# Altium schematic sheet TSAL_Rev3.SchDoc(TSAL_Rev3)
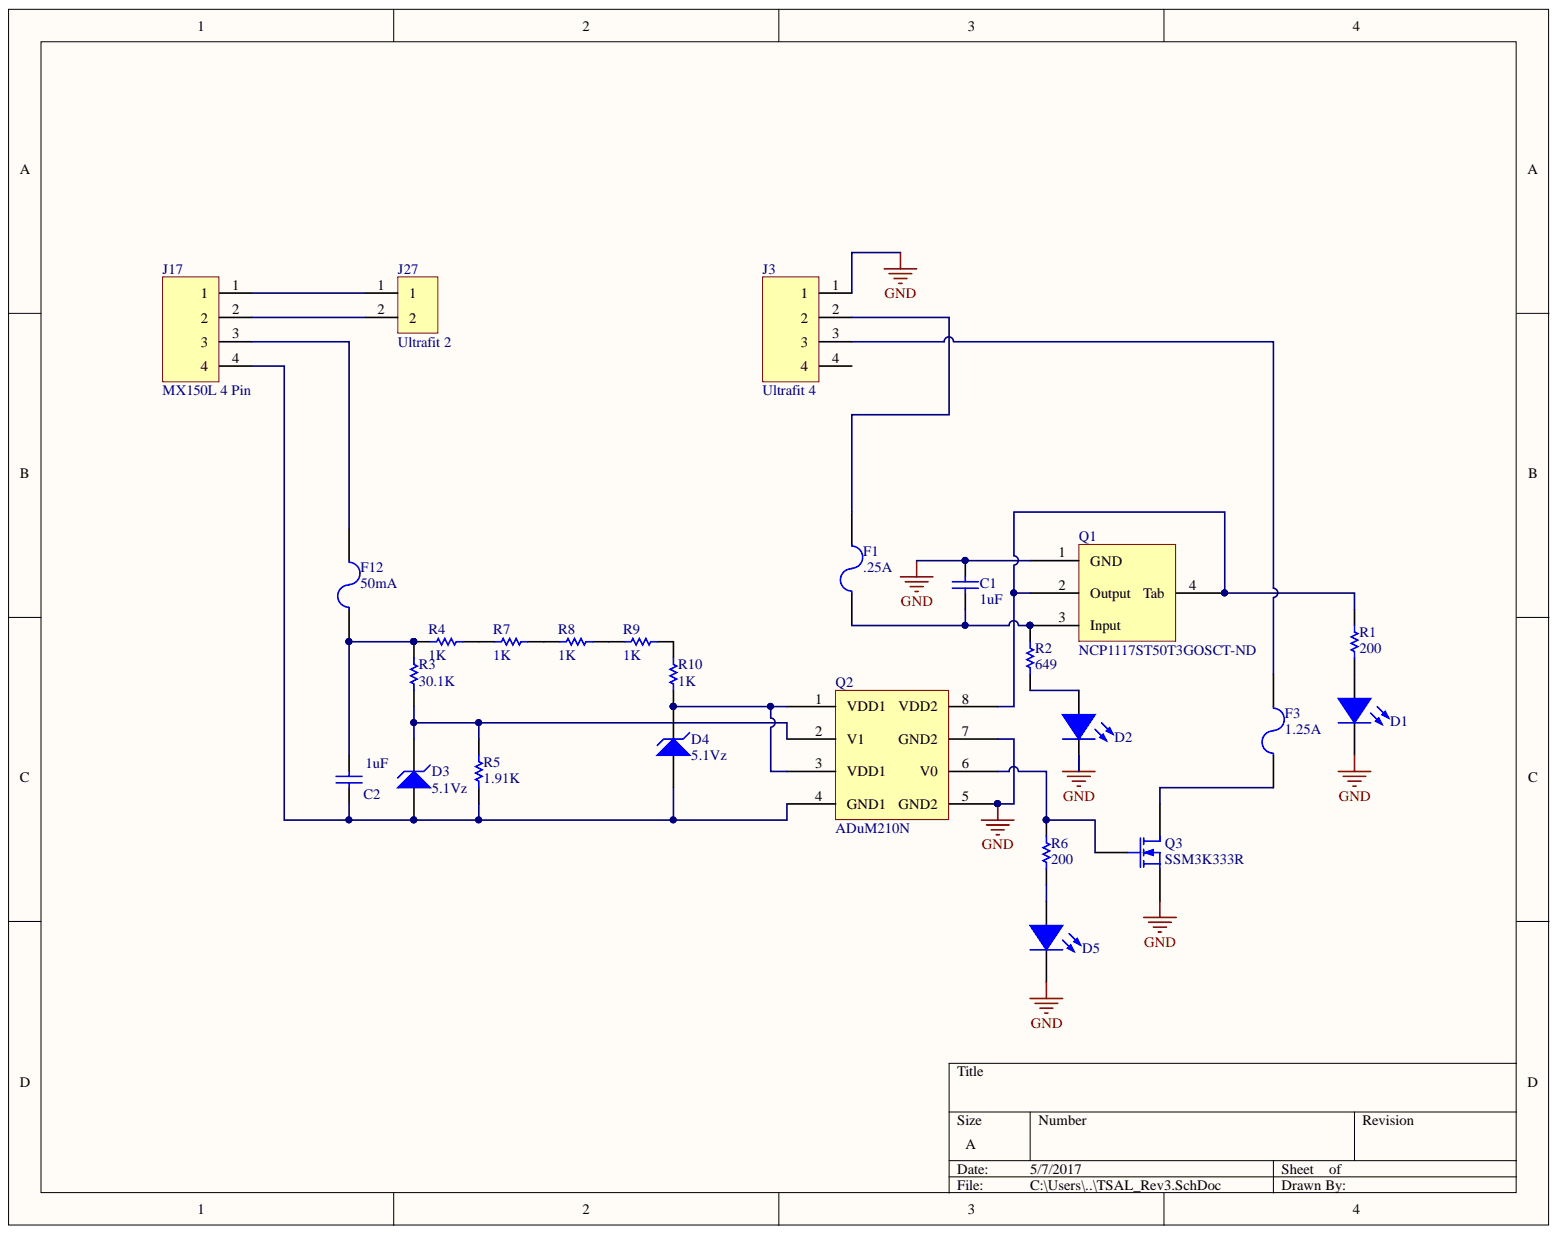
[diagram p0: TSAL_Rev3.SchDoc]

## Parts
C1  1uF  [1206 Cap]
C2  1uF  [2220 Cap]
D1  LED 0805  [0805_N - LED]  (value not drawn)
D2  LED 0805  [0805_N - LED]  (value not drawn)
D3  5.1Vz  [SOD123F]
D4  5.1Vz  [SOD123F]
D5  LED 0805  [0805_N - LED]  (value not drawn)
F1  .25A  [1206 Fuse]
F3  1.25A  [1812 Fuse]
F12  50mA  [Radial Fuse]
J3  Ultrafit 4  [4 Ultrafit]
J17  MX150L 4 Pin  [MX150L HV RA 4POS Connector]
J27  Ultrafit 2  [2 Ultrafit]
Q1  NCP1117ST50T3GOSCT-ND  [SOT223_M]
Q2  ADuM210N  [SOIC127P1040X265-8N]
Q3  SSM3K333R  [SOT-23 OEM]
R1  200  [0805 RES]
R2  649  [1206 Res]
R3  30.1K  [2512 RES]
R4  1K  [2512 RES]
R5  1.91K  [0805 RES]
R6  200  [0805 RES]
R7  1K  [2512 RES]
R8  1K  [2512 RES]
R9  1K  [2512 RES]
R10  1K  [2512 RES]

## Nets
GND: C1.2 D1.2 D2.2 D5.2 J3.1 Q1.1(GND) Q2.5(GND2) Q2.7(GND2) Q3.2
NetC1_1: C1.1 F1.1 Q1.3(Input) R2.2
NetC2_1: C2.1 D3.2 D4.2 J17.4 Q2.4(GND1) R5.1
NetC2_2: C2.2 F12.1 R3.2 R4.1
NetD1_1: D1.1 R1.1
NetD2_1: D2.1 R2.1
NetD3_1: D3.1 Q2.2(V1) R3.1 R5.2
NetD4_1: D4.1 Q2.1(VDD1) Q2.3(VDD1) R10.1
NetD5_1: D5.1 R6.1
NetF1_2: F1.2 J3.2
NetF3_1: F3.1 Q3.3
NetF3_2: F3.2 J3.3
NetF12_2: F12.2 J17.3
NetJ17_1: J17.1 J27.1
NetJ17_2: J17.2 J27.2
NetQ1_2: Q1.2(Output) Q1.4(Tab) Q2.8(VDD2) R1.2
NetQ2_6: Q2.6(V0) Q3.1 R6.2
NetR4_2: R4.2 R7.1
NetR7_2: R7.2 R8.1
NetR8_2: R8.2 R9.1
NetR9_2: R9.2 R10.2

Not connected: J3 4

## Library data of the parts
C1 = Cap 16V 1uF 10%: Surface mount capacitor; Value: 1uF; Supplier Part Number 1: 399-1254-1-ND; Supplier 1: Digi-Key; Component Kind: Standard; Library Name: Olin Electric Motorsports.IntLib
C2 = 445-7998-1-ND 1uF 450V 2220: Value: 1uF; Library Name: Integrated_Library.IntLib; Description: 445-7998-1-ND 1uF 450V 2220; Component Kind: Standard
D3 D4 = Diode Zener 5.1V: Value: 5.1Vz; Supplier Part Number 1: 568-6370-1-ND; Supplier 1: Digi-Key; Published: 8-Jun-2000; PackageReference: DIODE-0.7; PackageDescription: Diode, Thru-Hole; 2 Leads; 0.7 in Pin Spacing; LatestRevisionNote: Re-released for DXP Platform.; LatestRevisionDate: 17-Jul-2002; Description: Diode Zener 5.1V; Component Kind: Standard; Publisher: Altium Limited; Library Name: Olin Electric Motorsports.IntLib
F1 = PTC Fuse F2110CT-ND 16V .25A: Value: .25A; Supplier 1: Digi-Key; Library Name: Integrated_Library.IntLib; Description: PTC Fuse F2110CT-ND 16V .25A; Component Kind: Standard
F3 = PTC Fuse  F3779CT-ND 16V 1.25A: Value: 1.25A; Supplier 1: Digi-Key; Library Name: Integrated_Library.IntLib; Description: PTC Fuse  F3779CT-ND 16V 1.25A; Component Kind: Standard
F12 = PTC Fuse 495-3883-ND 380V 50mA: Value: 50mA; Supplier 1: Digi-Key; Library Name: Integrated_Library.IntLib; Description: PTC Fuse 495-3883-ND 380V 50mA; Component Kind: Standard
J3 = Ultrafit 4 pin: Supplier Part Number 2: WM11591-ND; Supplier Part Number 1: WM11574-ND; Supplier 2: Digi-Key; Supplier 1: Digi-Key; Description: Ultrafit 4 pin; Component Kind: Standard; Library Name: Olin Electric Motorsports.IntLib
J17 = MX150L HV RA 4POS Connector: Supplier Part Number 1: WM17505-ND; Supplier 1: Digi-Key; Library Name: Integrated_Library.IntLib; Description: MX150L HV RA 4POS Connector; Component Kind: Standard
J27 = Ultrafit 2 pin: Supplier Part Number 1: WM11570-ND; Supplier 1: Digi-Key; Description: Ultrafit 2 pin; Component Kind: Standard; Library Name: Olin Electric Motorsports.IntLib
Q1 = NCP1117ST50T3GOSCT-ND: NCP1117ST5 Voltage Regulator up to 20V to 5V; Library Name: Integrated_Library.IntLib; Component Kind: Standard
Q2 = ADuM210N Digital Isolator: Library Name: Integrated_Library.IntLib; Description: ADuM210N Digital Isolator; Component Kind: Standard
Q3 = MOSFET-N: N-Channel MOSFET; Supplier Part Number 1: SSM3K333RLFCT-ND; Supplier 1: Digi-Key; Component Kind: Standard; Library Name: Olin Electric Motorsports.IntLib
R1 R6 = RMCF0805JT200RCT-ND 200 1/8W 0805: RMCF0805JT200RCT-ND; Value: 200; Supplier 1: Digi-Key; Library Name: Integrated_Library.IntLib; Component Kind: Standard
R2 = Res 649 1%: 12V LED surface mount resistor; Value: 649; Supplier Part Number 1: 311-649FRCT-ND; Supplier 1: Digi-Key; Component Kind: Standard; Library Name: Olin Electric Motorsports.IntLib
R3 = 764-1284-1-ND 30.1K 6W 2512_1: 764-1284-1-ND; Value: 30.1K; Supplier 1: Digi-Key; Library Name: Integrated_Library.IntLib; Component Kind: Standard
R4 R7-R10 = Res 1K 3W 2512 5%: Value: 1K; Supplier Part Number: A121162CT-ND; Supplier Link: https://www.digikey.com/product-detail/en/te-connectivity-passive-product/35221K0JT/A121162CT-ND/5245219; Supplier Cost: 0.75; Supplier 1: Digi-Key; Library Name: Integrated_Library.IntLib; Description: Res 1K 3W 2512 5%; Component Kind: Standard
R5 = 311-1.91KCRCT-ND 1.91K 1/8W 0805_1: 311-1.91KCRCT-ND; Value: 1.91K; Supplier 1: Digi-Key; Library Name: Integrated_Library.IntLib; Component Kind: Standard
D1 D2 D5 = LED 0805: Supplier Part Number 1: 475-1410-1-ND; Supplier 1: Digi-Key; Description: LED 0805; Component Kind: Standard; Library Name: Olin Electric Motorsports.IntLib
